# Altium schematic sheet Sheet1.SchDoc
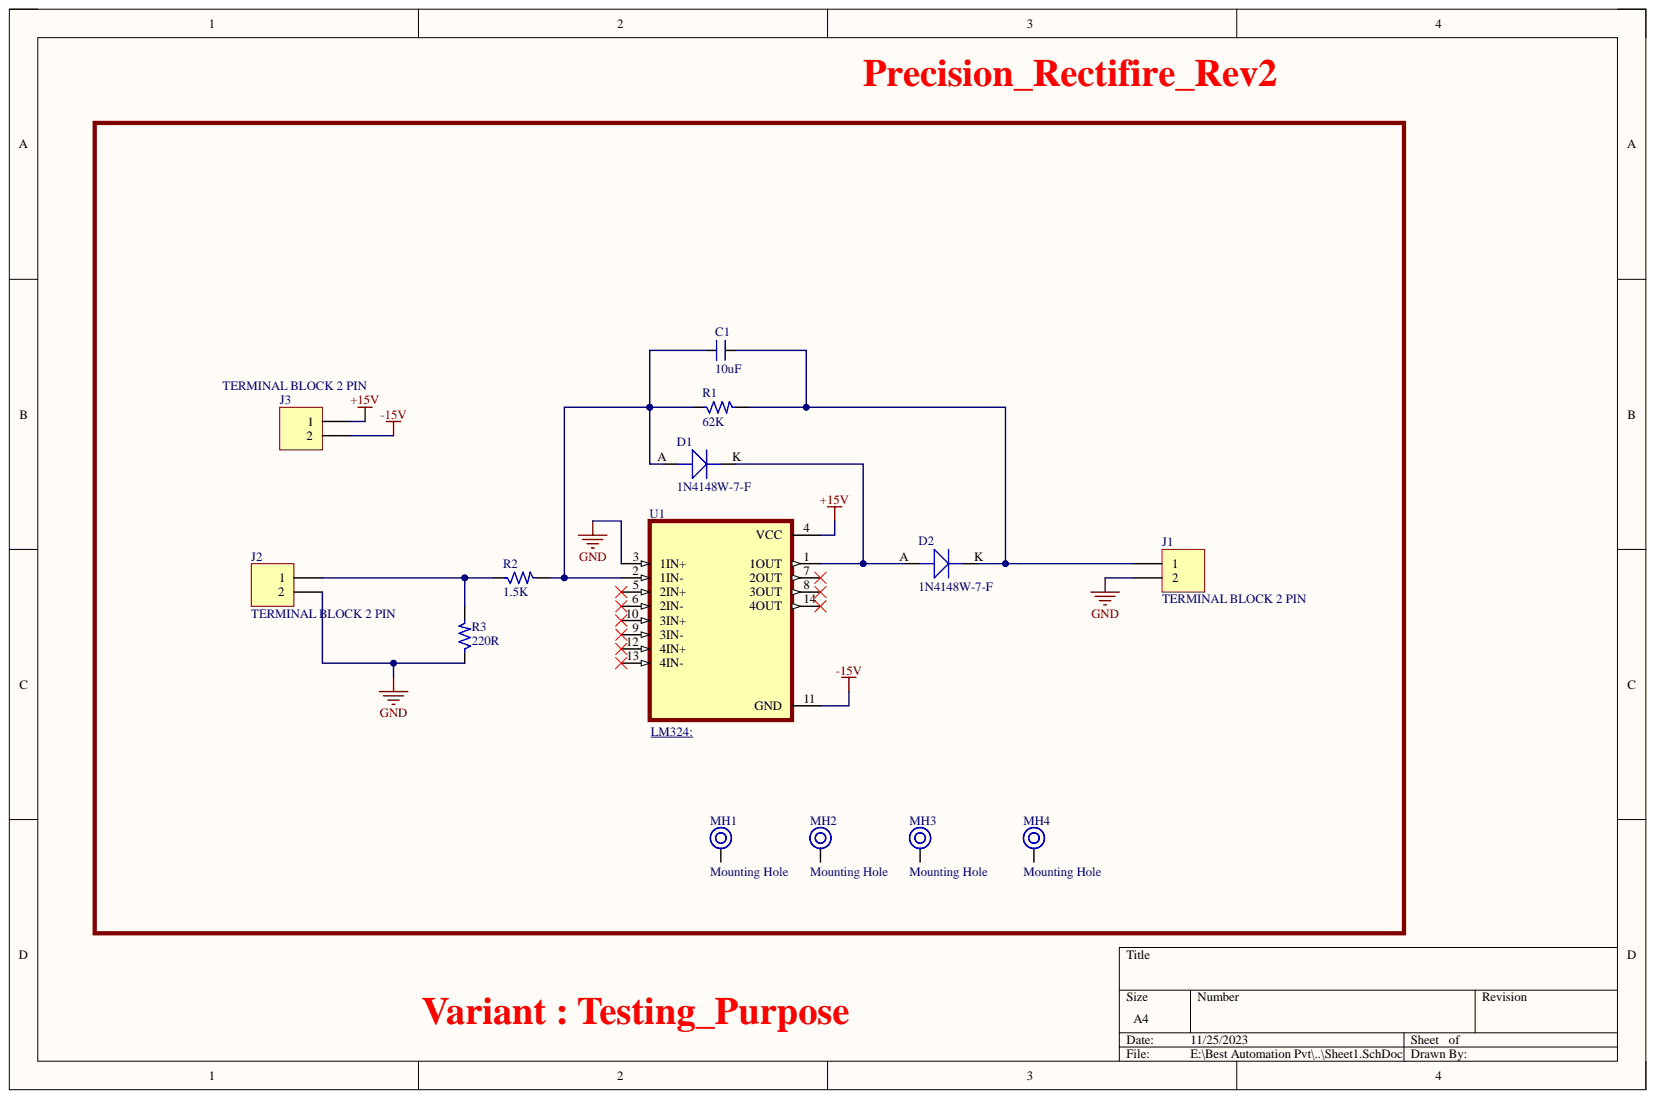
[diagram p0: Sheet1.SchDoc]

## Parts
C1  10uF  [CAP_P196_102X287_H332_RAD_TH]
D1  1N4148W-7-F  [DIO_DO-201AD_TH]
D2  1N4148W-7-F  [DIO_DO-201AD_TH]
J1  TERMINAL BLOCK 2 PIN  [CON2_P196_1729128_TH_ST]
J2  TERMINAL BLOCK 2 PIN  [CON2_P196_1729128_TH_ST]
J3  TERMINAL BLOCK 2 PIN  [CON2_P196_1729128_TH_ST]
MH1  Mounting Hole  [MOUNTING]
MH2  Mounting Hole  [MOUNTING]
MH3  Mounting Hole  [MOUNTING]
MH4  Mounting Hole  [MOUNTING]
R1  62K  [RES_539.3_TH]
R2  1.5K  [RES_539.3_TH]
R3  220R  [RES_539.3_TH]
U1  (no value)  [DIP14]

## Nets
+15V: J3.1 U1.4(VCC)
-15V: J3.2 U1.11(GND)
GND: J1.2 J2.2 R3.1 U1.3(1IN+)
NetC1_1: C1.1 D1.A R1.1 R2.2 U1.2(1IN-)
NetC1_2: C1.2 D2.K J1.1 R1.2
NetD1_K: D1.K D2.A U1.1(1OUT)
NetJ2_1: J2.1 R2.1 R3.2

Not connected: MH1 1; MH2 1; MH3 1; MH4 1; U1 5(2IN+) 6(2IN-) 7(2OUT) 8(3OUT) 9(3IN-) 10(3IN+) 12(4IN+) 13(4IN-) 14(4OUT)

## Library data of the parts
C1 = CAP_10uF-50V-10%-1206: 10µF ±10% 50V Ceramic Capacitor X7R 1206 (3216 Metric); Alternate Part Number-1: GRT31CR61H106ME01L; Manufacturer: KEMET; Manufacturer Part Number: CL31B106KBHNNNE; Case/Package: 1206; Component Kind: Standard; Library Name: ESTEEMPCBLIB.SchLib; Supplier Part Number-1: CC1206KKX5R9BB106; Supplier-1: SIRICOM
D1 D2 = DIO_100V-300mA-SOD123: Diode Standard 100V 300mA (DC) Surface Mount SOD-123; Alternate Part Number-1: NA; Manufacturer: FAIRCHILD; Case/Package: SOD123; Component Kind: Standard; Library Name: ESTEEMPCBLIB.SchLib; Manufacturer Part Number: 1N4148W-7-F; Supplier Part Number-1: 1N4148W-7-F; Supplier-1: SIRICOM
J1-J3 = CON-TB_2X1-196-ST-TH: 2 Pins, 1 Row 5mm Pitch, straight Connector, male; Alternate Part Number-1: NA; Manufacturer: Phoenix Contact; Manufacturer Part Number: MKDSN1,5/2; Case/Package: TH-CON; Component Kind: Standard; Library Name: ESTEEMPCBLIB.SchLib; Supplier Part Number-1: MKDSN1,5/2; Supplier-1: SP ROAD
MH1-MH4 = MH_3.5H_6D: Alternate Part Number-1: NA; Case/Package: TH; Component Kind: Standard; Description: Mounting Hole; Library Name: ESTEEMPCBLIB.SchLib; Manufacturer: NA; Manufacturer Part Number: NA; Supplier Part Number-1: NA; Supplier-1: NA
R1 = RES_64.9K-0.125W-1%-0805: 64.9 kOhms ±1% 0.125W, 1/8W Chip Resistor 0805 (2012 Metric) Moisture Resistant Thick Film; Alternate Part Number-1: NA; Manufacturer: Yageo; Manufacturer Part Number: RC0805FR-0764K9L; Case/Package: 0805; Component Kind: Standard; Library Name: ESTEEMPCBLIB.SchLib; Supplier Part Number-1: NA; Supplier-1: Siricom
R2 = RES_1.5K-0.125W-1%-0805: 1.5 kOhms ±1% 0.125W, 1/8W Chip Resistor 0805 (2012 Metric) Thick Film; Alternate Part Number-1: NA; Manufacturer: TE Connectivity Passive Product; Manufacturer Part Number: CRG0805F1K5; Case/Package: 0805; Component Kind: Standard; Library Name: ESTEEMPCBLIB.SchLib; Supplier Part Number-1: NA; Supplier-1: SIRICOM
R3 = RES_220R-0.5W-1%-TH: 220 Ohms ±1% 0.5W, 1/2W Through Hole Resistor Axial Flame Retardant Coating, Moisture Resistant, Safety Metal Film; Alternate Part Number-1: NA; Manufacturer: Ohmite; Manufacturer Part Number: CMF55220R00FHEK; Case/Package: TH; Component Kind: Standard; Library Name: ESTEEMPCBLIB.SchLib; Supplier Part Number-1: CMF55220R00FHEK; Supplier-1: Arrow
U1 = LM324DR: 4-Channel industry standard operational amplifier 14-SOIC 0 to 70; MF: Texas Instruments; Arrow_Electronics-Purchase-URL: https://snapeda.com/shop?store=Arrow+Electronics&id=1818; Availability: Good; Component Kind: Standard; DigiKey-Purchase-URL: https://snapeda.com/shop?store=DigiKey&id=1818; Library Name: ESTEEMPCBLIB.SchLib; Mouser-Purchase-URL: https://snapeda.com/shop?store=Mouser&id=1818; MP: LM324DR; Package: SOIC-14 Texas Instruments; Price: None; SnapEDA_Link: https://www.snapeda.com/parts/LM324DR/Texas%20Instruments/view-part/1818/?ref=snap; Texas_Instruments-Purchase-URL: https://snapeda.com/shop?store=Texas+Instruments&id=1818
